# Altium schematic sheet 06 Power Management.SchDoc
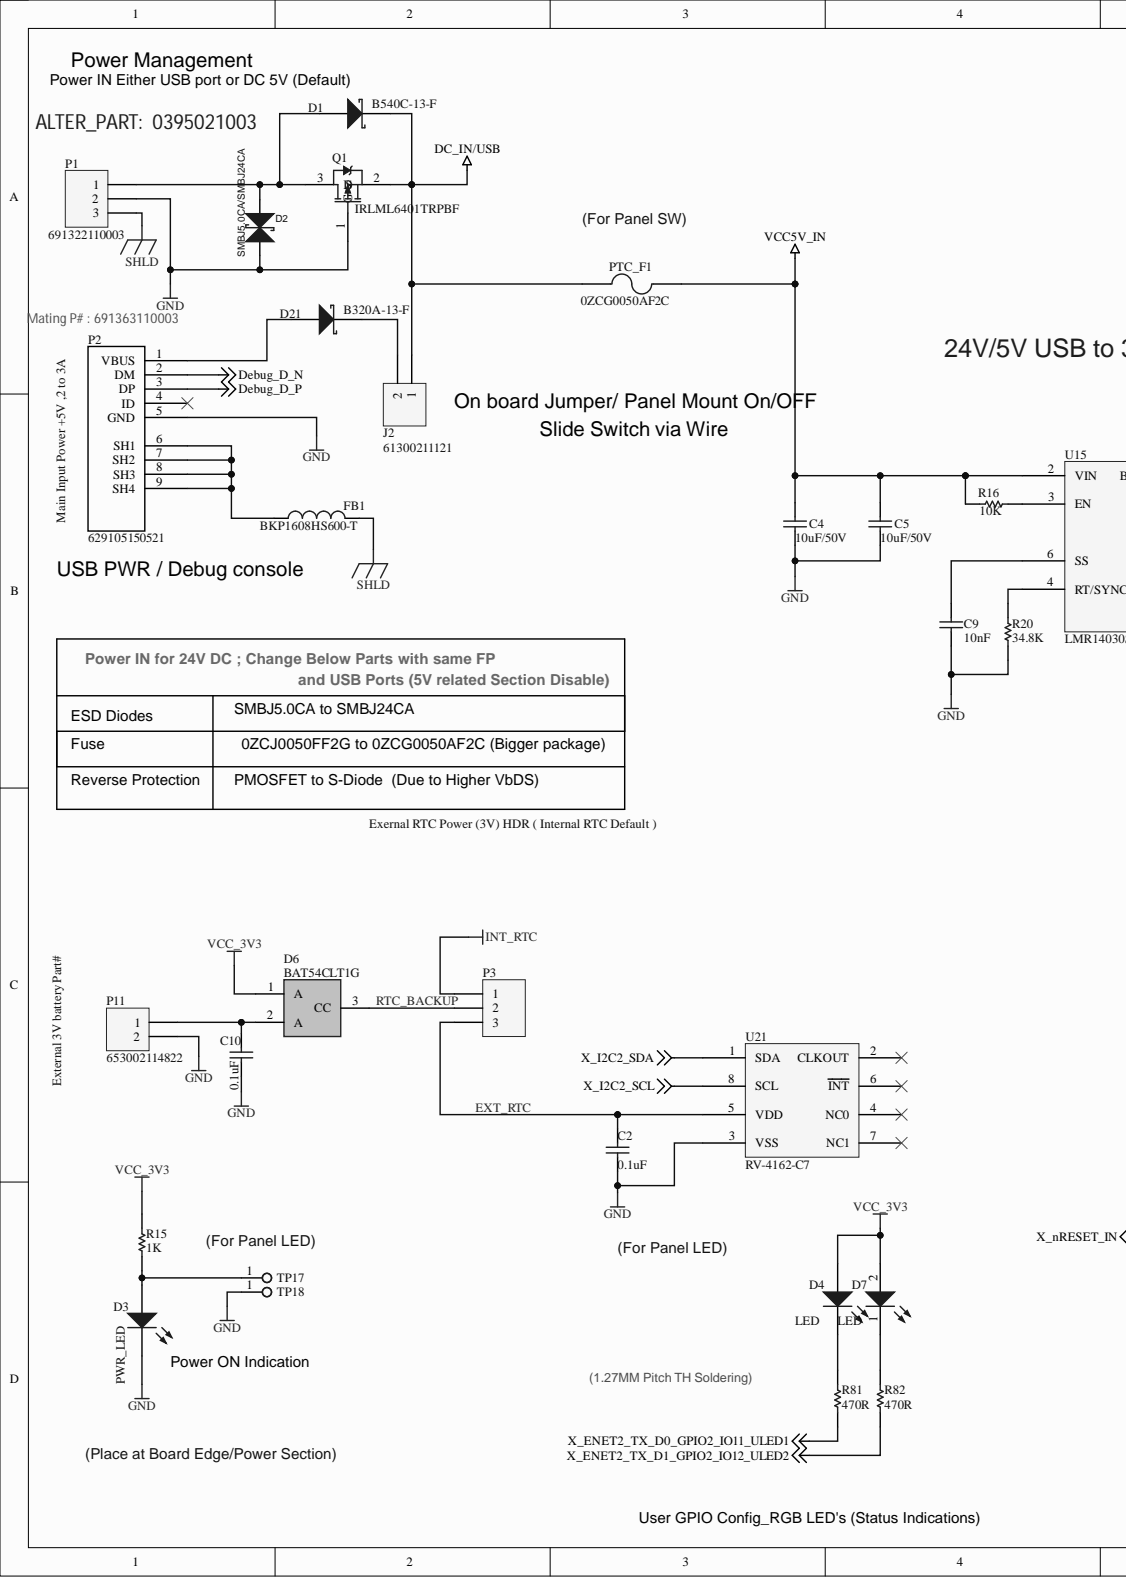
[diagram p0: 06 Power Management.SchDoc, left]
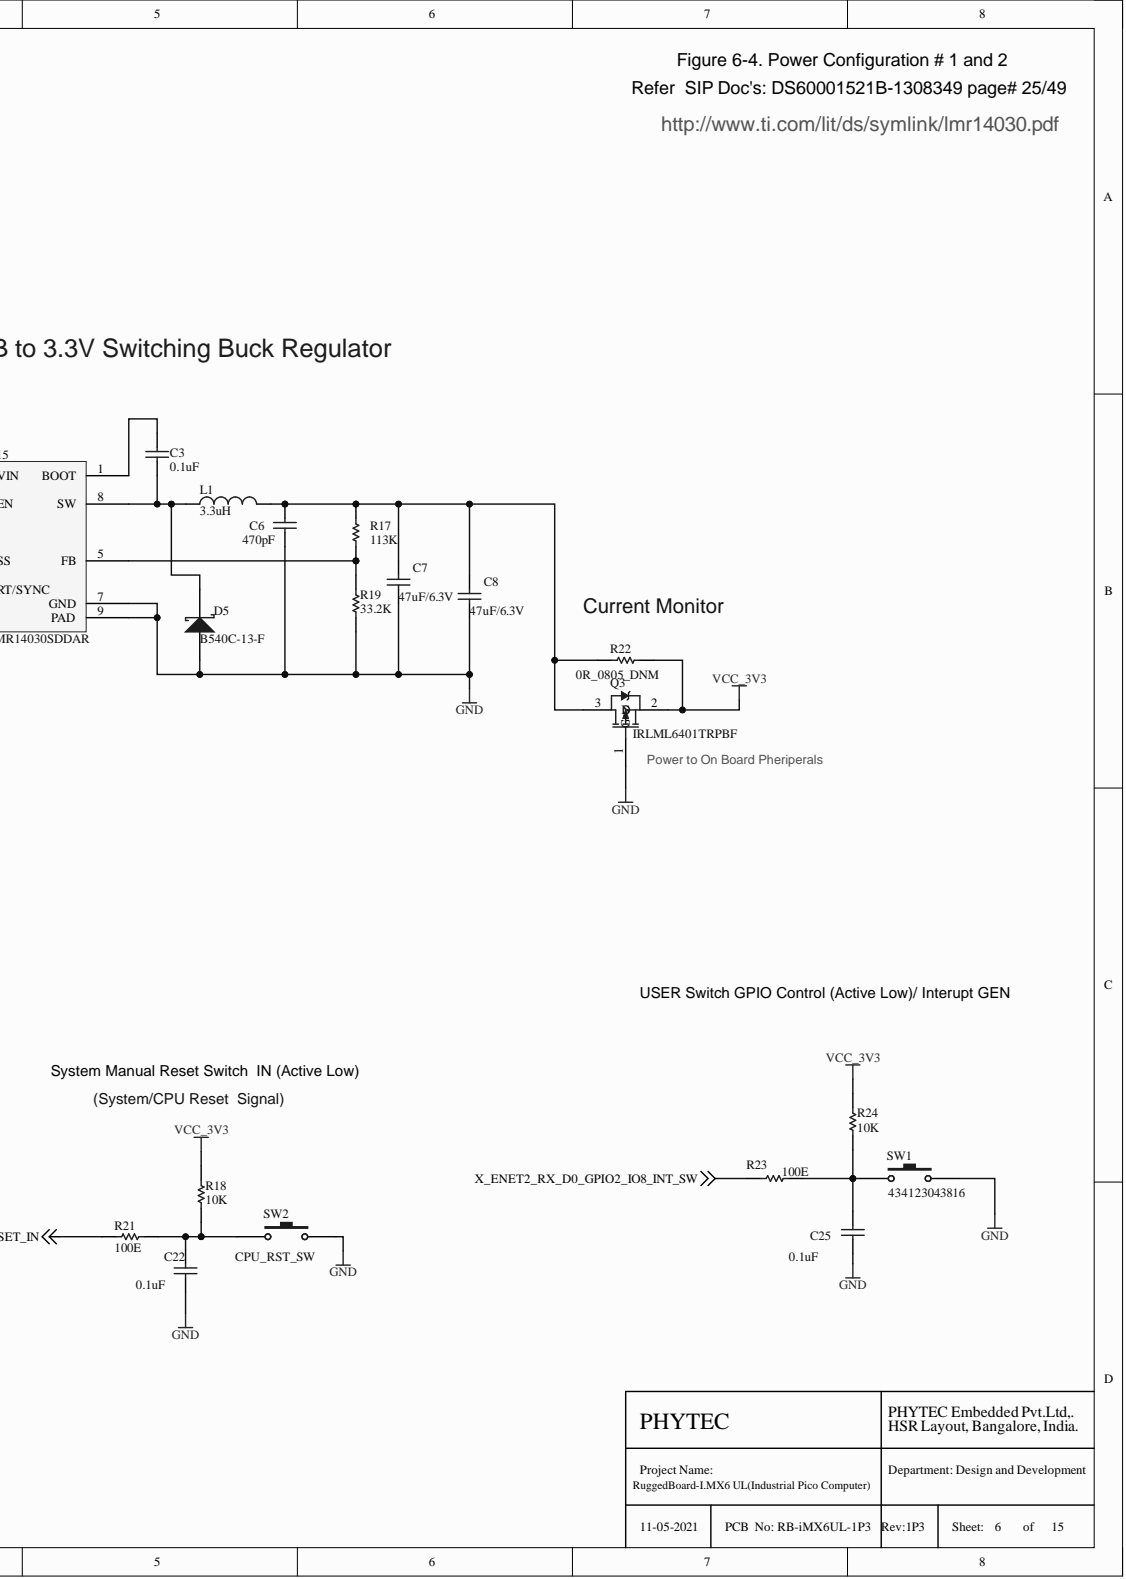
[diagram p1: 06 Power Management.SchDoc, right]

## Parts
C2  0.1uF  [C0402]
C3  0.1uF  [C0402]
C4  10uF/50V  [C1206]
C5  10uF/50V  [C1206]
C6  470pF  [C0402]
C7  47uF/6.3V  [C0805]
C8  47uF/6.3V  [C0805]
C9  10nF  [C0603]
C10  0.1uF  [C0402]
C22  0.1uF  [C0402]
C25  0.1uF  [C0402]
D1  B540C-13-F  [DIOM6959X25N]
D2  SMBJ5.0CA/SMBJ24CA  [DIOM4436X26N]
D3  PWR_LED  [1611]
D4  LED  [1611]
D5  B540C-13-F  [DIOM6959X25N]
D6  BAT54CLT1G  [SOT95P230X110-3N]
D7  LED  [1611]
D21  B320A-13-F  [SMA_1A_2K]
FB1  BKP1608HS600-T  [L0603]
J2  61300211121  [HDR1X2]
L1  3.3uH  [IND-IHLP-3232CZER3R3M01]
P1  691322110003  [TER_BLO_3PIN_3.5P_RA]
P2  629105150521  [629105150521]
P3  691322110003  [0603_3P]
P11  653002114822  [HEADER_2PIN_125P]
PTC_F1  0ZCG0050AF2C  [F1812]
Q1  IRLML6401TRPBF  [SOT95P230X110-3N]
Q3  IRLML6401TRPBF  [SOT95P230X110-3N]
R15  1K  [R0402]
R16  10K  [R0402]
R17  113K  [R0402]
R18  10K  [R0402]
R19  33.2K  [R0402]
R20  34.8K  [R0402]
R21  100E  [R0402]
R22  0R_0805_DNM  [R0805]
R23  100E  [R0402]
R24  10K  [R0402]
R81  470R  [R0402]
R82  470R  [R0402]
SW1  434123043816  [Tactile Switch SPST_EVQ-PE]
SW2  CPU_RST_SW  [Tactile Switch SPST_EVQ-PE]
TP17  Test Point  [TEST POINT PC MINI .040"D YELLOW]  (value not drawn)
TP18  Test Point  [TEST POINT PC MINI .040"D YELLOW]  (value not drawn)
U15  LMR14030SDDAR  [SO-Powerpad-127P600X175-9N]
U21  RV-4162-C7  [OSCCC320X150X80-8N]

## Nets
DC_IN/USB: D1.2 J2.1 PTC_F1.1 Q1.2
Debug_D_N: P2.2(DM)
Debug_D_P: P2.3(DP)
GND: C2.1 C4.1 C5.1 C6.2 C7.1 C8.1 C9.2 C10.1 C22.1 C25.1 D2.2 D3.1 D5.1 P1.2 P2.5(GND) P11.2 Q1.1 Q3.1 R19.2 R20.1 SW1.2 SW2.2 TP18.1 U15.7(GND) U15.9(PAD) U21.3(VSS)
INT_RTC: P3.1
SHLD: FB1.2 P1.3
VCC5V_IN: C4.2 C5.2 PTC_F1.2 R16.1 U15.2(VIN)
VCC_3V3: D4.2 D6.1 D7.2 Q3.2 R15.1 R18.1 R22.1 R24.1
X_ENET2_RX_D0_GPIO2_IO8_INT_SW: R23.2
X_ENET2_TX_D0_GPIO2_IO11_ULED1: R81.1
X_ENET2_TX_D1_GPIO2_IO12_ULED2: R82.1
X_I2C2_SCL: U21.8(SCL)
X_I2C2_SDA: U21.1(SDA)
X_nRESET_IN: R21.2
EXT_RTC: C2.2 P3.3 U21.5(VDD)
RTC_BACKUP: D6.3 P3.2
NetC3_1: C3.1 D5.2 L1.1 U15.8(SW)
NetC3_2: C3.2 U15.1(BOOT)
NetC6_1: C6.1 C7.2 C8.2 L1.2 Q3.3 R17.1 R22.2
NetC9_1: C9.1 U15.6(SS)
NetC10_2: C10.2 D6.2 P11.1
NetC22_2: C22.2 R18.2 R21.1 SW2.1
NetC25_2: C25.2 R23.1 R24.2 SW1.1
NetD1_1: D1.1 D2.1 P1.1 Q1.3
NetD3_2: D3.2 R15.2 TP17.1
NetD4_1: D4.1 R81.2
NetD7_1: D7.1 R82.2
NetD21_1: D21.1 P2.1(VBUS)
NetD21_2: D21.2 J2.2
NetFB1_1: FB1.1 P2.6(SH1) P2.7(SH2) P2.8(SH3) P2.9(SH4)
NetR16_2: R16.2 U15.3(EN)
NetR17_2: R17.2 R19.1 U15.5(FB)
NetR20_2: R20.2 U15.4(RT/SYNC)

Not connected: P2 4(ID); U21 2(CLKOUT) 4(NC0) 6(INT) 7(NC1)

## Library data of the parts
C2 = Cap: CAP CER 0.1UF 50V X7R 0402; ASSEMBLY_TYPE: SMT; MACRO: Power Management (VYS, 5V, 3.3V and Reset); MFG: Taiyo Yuden; MFG_PN: UMK105B7104KV-FR; Component Kind: Standard; Library Name: Miscellaneous Devices.IntLib; PACKAGE: 0402; Signal Integrity: Cap; Simulation: CAP; TEMPERATURE: -55°C ~ 125°C
C3 = Cap: CAP CER 0.1UF 50V X7R 0402; ASSEMBLY_TYPE: SMT; MACRO: Power Management (VYS, 5V, 3.3V and Reset); MFG: Murata; MFG_PN: GRM155R71H104ME14D; Component Kind: Standard; Library Name: Miscellaneous Devices.IntLib; PACKAGE: 0402; Signal Integrity: Cap; Simulation: CAP; TEMPERATURE: -55°C ~ 125°C
C4 C5 = Cap: CAP CER 10UF 50V X5R 1206; ASSEMBLY_TYPE: SMD; MFG: MURATA; MFG_PN: GRM31CR61H106KA12L; Component Kind: Standard; Library Name: Miscellaneous Devices.IntLib; PACKAGE: 1206; Signal Integrity: Cap; Simulation: CAP; TEMPERATURE: -55°C ~ 85°C
C6 = Cap: CAP CER 470PF 50V C0G/NP0 0402; ASSEMBLY_TYPE: SMT; MACRO: Power Management (VYS, 5V, 3.3V and Reset); MFG: Murata; MFG_PN: GRM1555C1H471FA01D; Component Kind: Standard; Library Name: Miscellaneous Devices.IntLib; PACKAGE: 0402; Signal Integrity: Cap; Simulation: CAP; TEMPERATURE: -55°C ~ 125°C
C7 C8 = Cap Semi: CAP CER 47UF 6.3V X5R 0805; ASSEMBLY_TYPE: SMT; MFG: Murata; MFG_PN: GRM21BR60J476ME15L; Component Kind: Standard; Library Name: Miscellaneous Devices.IntLib; PACKAGE: 0805
C9 = Cap: CAP CER 10000PF 50V X7R 0603; ASSEMBLY_TYPE: SMT; MFG: Murata; MFG_PN: GRM188R71H103KA01D; Component Kind: Standard; Library Name: Miscellaneous Devices.IntLib; PACKAGE: 0603; TEMPERATURE: -55°C ~ 125°C
C10 C22 C25 = Cap: CAP CER 0.1UF 50V X7R 0402; ASSEMBLY_TYPE: SMT; MACRO: Mini PCIe CONN; MFG: Murata; MFG_PN: GRM155R71H104ME14D; Component Kind: Standard; Library Name: Miscellaneous Devices.IntLib; PACKAGE: 0402; Signal Integrity: Cap; Simulation: CAP
D1 = D Schottky: DIODE SCHOTTKY 40V 5A SMC; ASSEMBLY_TYPE: SMD; MFG: DIODES INC; Component Kind: Standard; Library Name: Miscellaneous Devices.IntLib; MFG_PN: B540C-13-F; PACKAGE: DO-214AB; Signal Integrity: D Schottky; Simulation: SKYDIODE
D2 = D-Littelfuse-SMAJ30CA-Schottky: TVS DIODE 5V 9.2V DO214AA; ASSEMBLY_TYPE: SMT; MACRO: POWER MANAGEMENT; MFG: Littelfuse Inc.; MFG2_PN: 824521500; Component Kind: Standard; MFG2: WE; MFG_PN: SMBJ5.0CA; PACKAGE: DO-214AA; TEMPERATURE: -55°C ~ 125°C; Library Name: Apalis Evaluation Board.SchLib
D3 = LED3: LED RED CLEAR 0603 SMD; ASSEMBLY_TYPE: SMT; MFG: Lite-On Inc.; MFG_PN: LTST-C191KRKT; Component Kind: Standard; Library Name: Miscellaneous Devices.IntLib; PACKAGE: 0603
D4 D7 = LED3: LED RED CLEAR 0603 SMD; ASSEMBLY_TYPE: SMT; MFG: Lite-On Inc.; MFG_PN: LTST-C191KGKT; Component Kind: Standard; Library Name: Miscellaneous Devices.IntLib; PACKAGE: 0603
D5 = D Schottky: DIODE SCHOTTKY 40V 5A SMC; MACRO: Power Management (VYS, 5V, 3.3V and Reset); MFG: DIODES INC; Component Kind: Standard; Library Name: Miscellaneous Devices.IntLib; MFG_PN: B540C-13-F; PACKAGE: DO-214AB; Signal Integrity: D Schottky; Simulation: SKYDIODE; TEMPERATURE: -55°C ~ 125°C
D6 = BAT54C: DIODE ARRAY SCHOTTKY 30V SOT23-3; ASSEBMLY_TYPE: SMD; MFG: ON Semiconductor; Component Kind: Standard; Library Name: Bat11.SchLib; MFG_PN: BAT54CLT1G; PACKAGE: SOT23-3
D21 = D Schottky: DIODE SCHOTTKY 20V 3A SMA; ASSEMBLY_TYPE: SMD; MFG: DIODES INC; Component Kind: Standard; Library Name: Miscellaneous Devices.IntLib; MFG_PN: B320A-13-F; PACKAGE: DO-214AC; Signal Integrity: D Schottky; Simulation: SKYDIODE
FB1 = Inductor: FERRITE BEAD 60 OHM 0603 1LN; ASSEMBLY_TYPE: SMT; MACRO: Power For Ethernet PHY Transceiver; MFG: Taiyo Yuden; Component Kind: Standard; Library Name: Miscellaneous Devices.IntLib; MFG_PN: BKP1608HS600-T; PACKAGE: 0603; Signal Integrity: Inductor; Simulation: INDUCTOR; TEMPERATURE: -55°C ~ 85°C
J2 = Header 2: CONN HEADER VERT 2POS 2.54MM; ASSEMBLY_TYPE: TH; MFG: WE; Component Kind: Standard; Library Name: Miscellaneous Connectors.IntLib; MFG_PN: 61300211121
L1 = Inductor: FIXED IND 3.3UH 7A 27.4 MOHM SMD; ASSEMBLY_TYPE: SMT; KHK_AN: LS316; MACRO: Power Management (VYS, 5V, 3.3V and Reset); MFG: WE; MFG_PN: 74437356033; Component Kind: Standard; Library Name: Miscellaneous Devices.IntLib; PACKAGE: SMT; Signal Integrity: Inductor; Simulation: INDUCTOR; TEMPERATURE: -55°C ~ 125°C
P1 P3 = Header 3H: TERM BLOCK HDR 3POS 90DEG 3.5MM; MFG: Wurth Electronics Inc.; Component Kind: Standard; Library Name: Miscellaneous Connectors.IntLib; MFG_PN: 691322110003
P2 = 101181940001LF: CONN USB MICRO B RECPT SMT R/A; MFG: Würth Elektronik; Component Kind: Standard; MFG_PN: 629105150521; PACKAGE: TH; Library Name: irco.SchLib
P11 = Header 2: 2 Positions Header, Shrouded Connector 0.049" (1.25mm) Through Hole Tin; ASSEMBLY_TYPE: TH; MFG: WE; Component Kind: Standard; Library Name: Miscellaneous Connectors.IntLib; MFG_PN: 653002114822; PACKAGE: TH; Signal Integrity: Connector
PTC_F1 = Fuse 2: PTC RESET FUSE 30V 500MA 1812; ASSEMBLY_TYPE: SMT; MACRO: Power Management; MFG: Bel Fuse Inc.; Component Kind: Standard; Library Name: Miscellaneous Devices.IntLib; MFG_PN: 0ZCG0050AF2C; PACKAGE: SMT
Q1 Q3 = PMOS-2: MOSFET P-CH 12V 4.3A SOT-23; ASSEMBLY_TYPE: SMT; MFG: Infineon Technologies; Component Kind: Standard; Library Name: SCH_LIBRARY.SCHLIB; MFG_PN: IRLML6401TRPBF; PACKAGE: SOT-23; Simulation: IRF9510; TEMPERATURE: -55°C ~ 150°C (TJ)
R15 = Res1: RES SMD 1K OHM 1% 1/16W 0402; MFG: YAGEO; MFG_PN: RC0402FR-071KL; Component Kind: Standard; Library Name: Miscellaneous Devices.IntLib; PACKAGE: 0402; PACKAGE_TYPE: SMT; Signal Integrity: Res; Simulation: RESISTOR
R16 = Res1: RES SMD 10K OHM 1% 1/16W 0402; ASSEMBLY_TYPE: SMT; KHK_AN: RM779; MACRO: Power Management (VYS, 5V, 3.3V and Reset); MFG: YAGEO; MFG_PN: RC0402FR-0710KL; Component Kind: Standard; Library Name: Miscellaneous Devices.IntLib; PACKAGE: 0402; Signal Integrity: Res; Simulation: RESISTOR; TEMPERATURE: -55°C ~ 155°C
R17 = Res1: RES SMD 113K OHM 1% 1/16W 0402; ASSEMBLY_TYPE: SMT; MACRO: Power Management (VYS, 5V, 3.3V and Reset); MFG: YAGEO; MFG_PN: RC0402FR-07113KL; Component Kind: Standard; Library Name: Miscellaneous Devices.IntLib; PACKAGE: 0603; TEMPERATURE: -55°C ~ 155°C
R18 R24 = Res1: RES SMD 10K OHM 1% 1/16W 0402; ASSEMBLY_TYPE: SMT; MFG: YAGEO; MFG_PN: RC0402FR-0710KL; Component Kind: Standard; Library Name: Miscellaneous Devices.IntLib; PACKAGE: 0402; Signal Integrity: Res; Simulation: RESISTOR
R19 = Res1: RES SMD 33.2K OHM 1% 1/16W 0402; ASSEMBLY_TYPE: SMT; MACRO: Power Management (VYS, 5V, 3.3V and Reset); MFG: YAGEO; MFG_PN: RC0402FR-0733K2L; Component Kind: Standard; Library Name: Miscellaneous Devices.IntLib; PACKAGE: 0402; Signal Integrity: Res; Simulation: RESISTOR; TEMPERATURE: -55°C ~ 155°C
R20 = Res1: RES SMD 34.8K OHM 1% 1/16W 0402; ASSEMBLY_TYPE: SMT; KHK_AN: RM1263; MACRO: Power Management (VYS, 5V, 3.3V and Reset); MFG: YAGEO; MFG_PN: RC0402FR-0734K8L; Component Kind: Standard; Library Name: Miscellaneous Devices.IntLib; PACKAGE: 0402; Signal Integrity: Res; Simulation: RESISTOR; TEMPERATURE: -55°C ~ 155°C
R21 R23 = Res1: RES SMD 100 OHM 1% 1/16W 0402; MFG: YAGEO; MFG_PN: RC0402FR-07100RL; Component Kind: Standard; Library Name: Miscellaneous Devices.IntLib; PACKAGE: 0402; PACKAGE_TYPE: SMT; Signal Integrity: Res; Simulation: RESISTOR
R22 = Res1: RES SMD 0.0OHM JUMPER 1/8W 0805; ASSEMBLY_TYPE: SMT; MACRO: Power LDO 5V0 and 3V3 (L7986); MFG: Yageo; MFG_PN: AC0805JR-070RL; Component Kind: Standard; Library Name: Miscellaneous Devices.IntLib; PACKAGE: 0805; TEMPERATURE: -55°C ~ 155°C
R81 R82 = Res1: RES SMD 470 OHM 0.1% 1/16W 0402; ASSEMBLY_TYPE: SMT; ENV_REPORT: Lead free / RoHS Compliant; MFG: Yageo; MFG_PN: RT0402BRD07470RL; Component Kind: Standard; Digi-Key Part#: YAG4268CT-ND; Library Name: Miscellaneous Devices.IntLib; PACKAGE: 0402; TEMPERATURE: -55°C ~ 155°C
SW1 = SW-PB: SWITCH TACTILE SPST-NO 0.05A 12V; ASSEMBLY_TYPE: SMD; MFG: Panasonic Electronic Components; Component Kind: Standard; Library Name: Miscellaneous Devices.IntLib; MFG_PN: 434123043816; PACKAGE: SMD
SW2 = SW-PB: SWITCH TACTILE SPST-NO 0.05A 12V; ASSEMBLY_TYPE: SMD; MFG: Panasonic Electronic Components; MFG_PN: EVQ-PE104K; Component Kind: Standard; Library Name: Miscellaneous Devices.IntLib; PACKAGE: SMD
TP17 TP18 = TP: TEST POINT PC MINI .040"D RED; ASSEMBLY_TYPE: TH; MACRO: Power Management (VYS, 5V, 3.3V and Reset); MFG: Keystone; MFG_PN: 5000; Component Kind: Standard; PACKAGE: Assembly; TEMPERATURE: -55°C ~ 155°C; Library Name: HTT SoM - Sept 7 2016 V1P2 .SCHLIB
U15 = LMR14030SDDAR: IC REG BUCK ADJ 3.5A; MACRO: Power Management (VYS, 5V, 3.3V and Reset); MFG: TI; Component Kind: Standard; Library Name: TVS i,MX6 Mother Board.SCHLIB; MFG_PN: LMR14030SDDAR; PACKAGE: 8-SO; TEMPERATURE: -40°C ~ 125°C (TJ)
U21 = Component_1: RTC IC; ASSEMBLY_TYPE: SMT; MFG: Micro Crystal; Component Kind: Standard; Library Name: rtc 4162-C7.SchLib; MFG_PN: RV-4162-C7; PACKAGE: C7 Package
